# Altium schematic sheet 4_POWER.SchDoc(4_POWER)
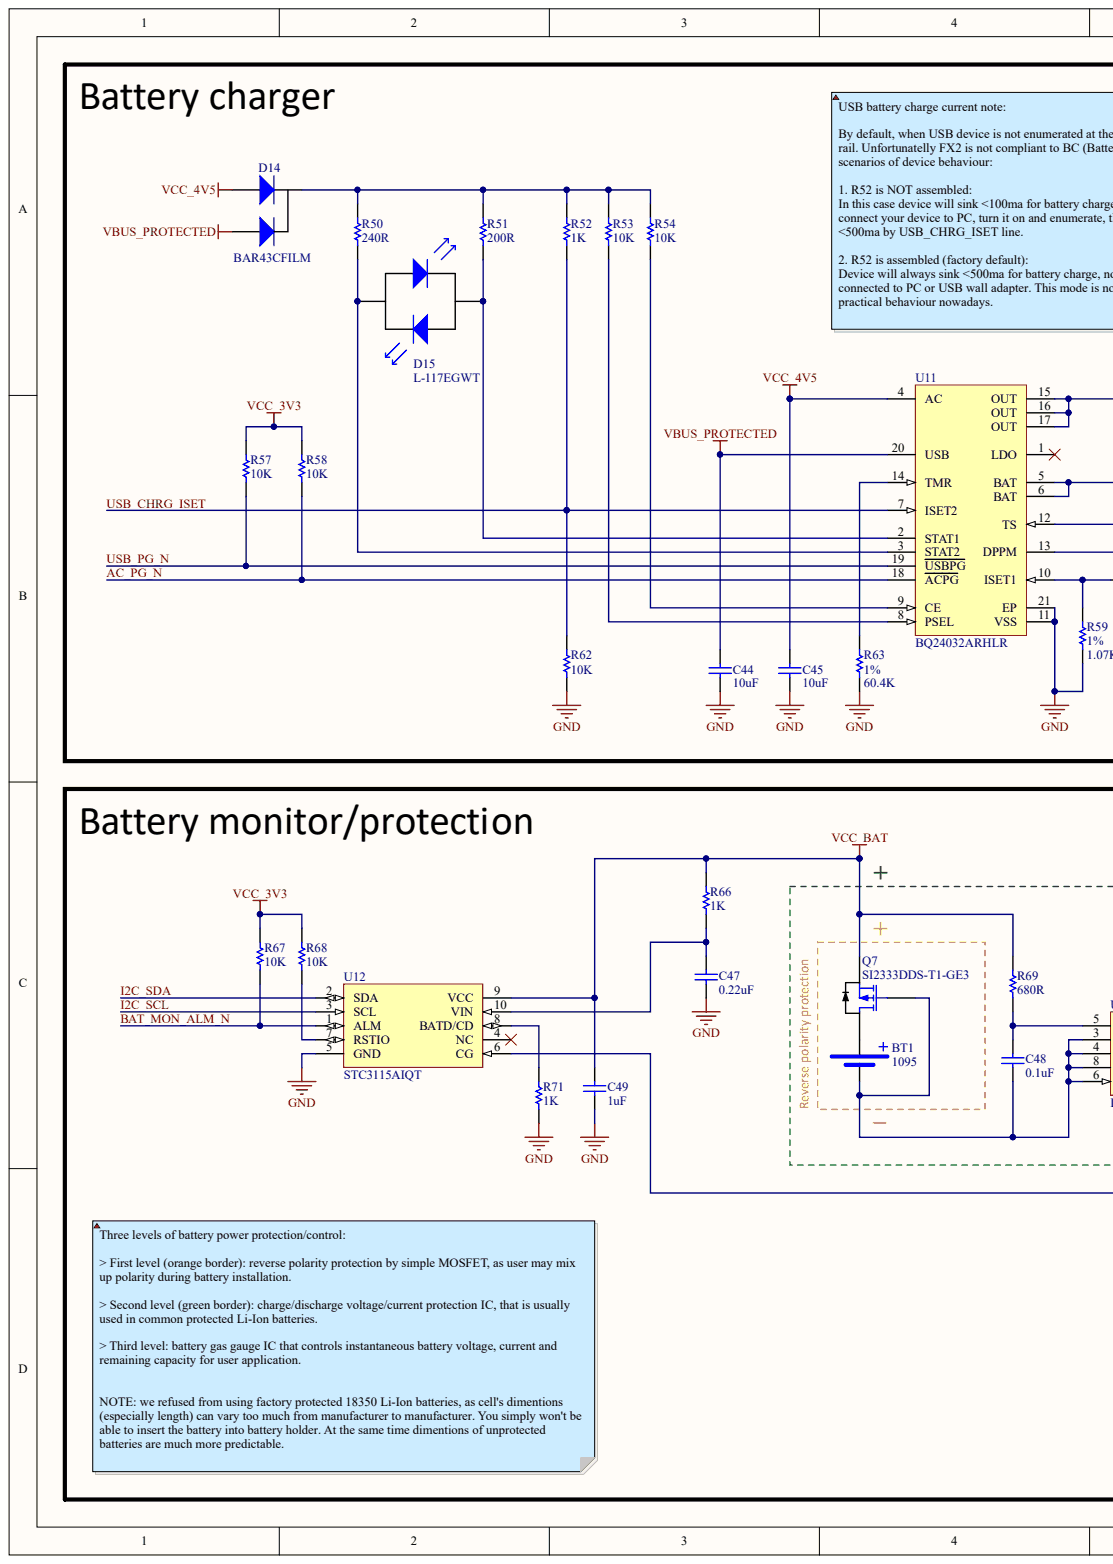
[diagram p0: 4_POWER.SchDoc, left]
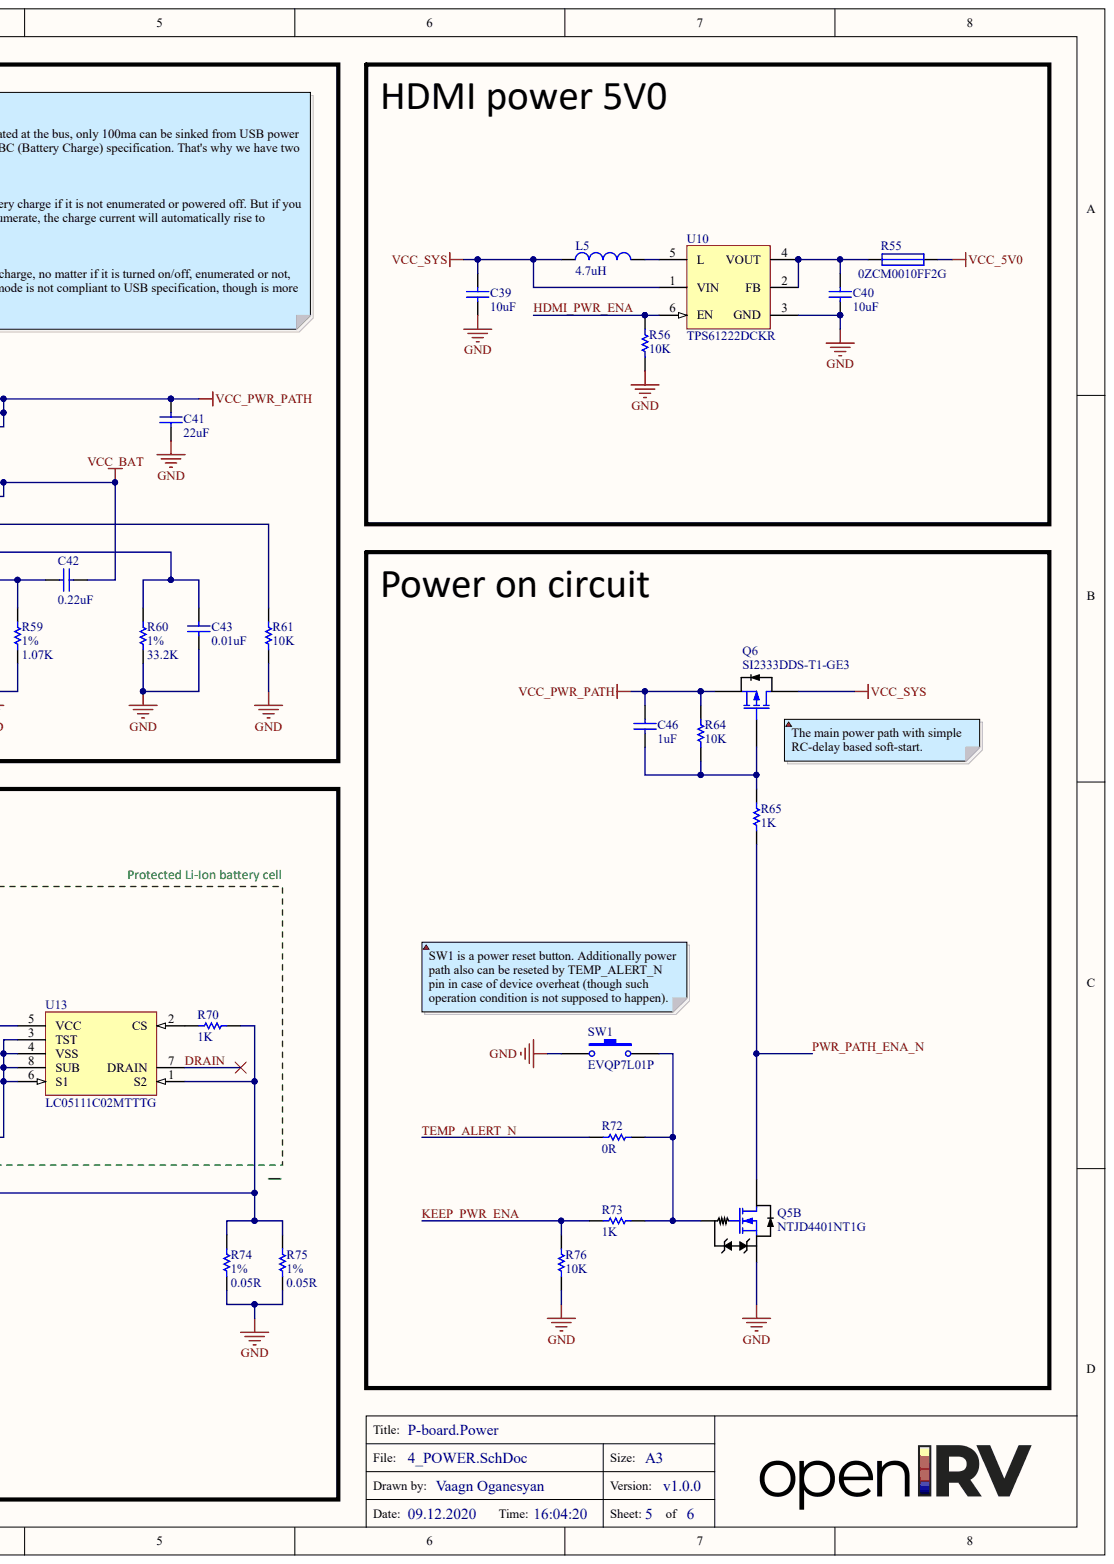
[diagram p1: 4_POWER.SchDoc, right]

## Parts
BT1  1095  [1095]
C39  10uF  [C0805[2012]]
C40  10uF  [C0805[2012]]
C41  22uF  [C1206[3216]]
C42  0.22uF  [C0603[1608]]
C43  0.01uF  [C0402[1005]]
C44  10uF  [C0805[2012]]
C45  10uF  [C0805[2012]]
C46  1uF  [C0603[1608]]
C47  0.22uF  [C0603[1608]]
C48  0.1uF  [C0402[1005]]
C49  1uF  [C0603[1608]]
D14  BAR43CFILM  [BAR43CFILM]
D15  L-117EGWT  [LED_AXIAL[7x5x2]]
L5  value 4.7uH  [L0805[2012]]  (hidden comment: LQM21PN4R7MGRD)
Q5  NTJD4401NT1G  [NTJD4401NT1G]  (part B)
Q6  SI2333DDS-T1-GE3  [SI2333DDS-T1-GE3]
Q7  SI2333DDS-T1-GE3  [SI2333DDS-T1-GE3]
R50  240R  [R0603[1608]]
R51  200R  [R0603[1608]]
R52  1K  [R0402[1005]]
R53  10K  [R0402[1005]]
R54  10K  [R0402[1005]]
R55  0ZCM0010FF2G  [R0603[1608]]
R56  10K  [R0402[1005]]
R57  10K  [R0402[1005]]
R58  10K  [R0402[1005]]
R59  1.07K  Tolerance: 1%  [R0603[1608]]
R60  33.2K  Tolerance: 1%  [R0603[1608]]
R61  10K  [R0402[1005]]
R62  10K  [R0402[1005]]
R63  60.4K  Tolerance: 1%  [R0603[1608]]
R64  10K  [R0402[1005]]
R65  1K  [R0402[1005]]
R66  1K  [R0402[1005]]
R67  10K  [R0402[1005]]
R68  10K  [R0402[1005]]
R69  680R  [R0603[1608]]
R70  1K  [R0402[1005]]
R71  1K  [R0402[1005]]
R72  0R  [R0402[1005]]
R73  1K  [R0402[1005]]
R74  0.05R  Tolerance: 1%  [R1206[3216]]
R75  0.05R  Tolerance: 1%  [R1206[3216]]
R76  10K  [R0402[1005]]
SW1  EVQP7L01P  [EVQP7L01P]
U10  TPS61222DCKR  [TPS61222DCKR]
U11  BQ24032ARHLR  [BQ24032ARHLR]
U12  STC3115AIQT  [STC3115AIQT]
U13  LC05111C02MTTTG  [LC05111C02MTTTG]

## Nets
GND: C39.1 C40.1 C41.1 C43.1 C44.1 C45.1 C47.1 C49.2 Q5.4 R56.2 R59.2 R60.2 R61.1 R62.2 R63.2 R71.1 R74.2 R75.2 R76.1 SW1.2 U10.3(GND) U11.11(VSS) U11.21(EP) U12.5(GND)
VBUS_PROTECTED: C44.2 D14.2 U11.20(USB)
VCC_3V3: R57.2 R58.2 R67.2 R68.2
VCC_4V5: C45.2 D14.1 U11.4(AC)
VCC_5V0: R55.2
VCC_BAT: C42.2 C49.1 Q7.2 R66.2 R69.1 U11.5(BAT) U11.6(BAT) U12.9(VCC)
VCC_PWR_PATH: C41.2 C46.1 Q6.2 R64.1 U11.15(OUT) U11.16(OUT) U11.17(OUT)
VCC_SYS: C39.2 L5.1 Q6.3 U10.1(VIN)
AC_PG_N: R58.1 U11.18(ACPG)
BAT_MON_ALM_N: R67.1 U12.1(ALM)
DRAIN: U13.7(DRAIN)
HDMI_PWR_ENA: R56.1 U10.6(EN)
I2C_SCL: U12.3(SCL)
I2C_SDA: U12.2(SDA)
KEEP_PWR_ENA: R73.2 R76.2
PWR_PATH_ENA_N: Q5.3 R65.2
TEMP_ALERT_N: R72.2
USB_CHRG_ISET: R52.1 R62.1 U11.7(ISET2)
USB_PG_N: R57.1 U11.19(USBPG)
NetBT1_1: BT1.1 Q7.3
NetBT1_2: BT1.2 C48.2 Q7.1 U13.3(TST) U13.4(VSS) U13.6(S1) U13.8(SUB)
NetC40_2: C40.2 R55.1 U10.2(FB) U10.4(VOUT)
NetC42_1: C42.1 R59.1 U11.10(ISET1)
NetC43_2: C43.2 R60.1 U11.13(DPPM)
NetC46_2: C46.2 Q6.1 R64.2 R65.1
NetC47_2: C47.2 R66.1 U12.10(VIN)
NetC48_1: C48.1 R69.2 U13.5(VCC)
NetD14_3: D14.3 R50.1 R51.1 R52.2 R53.2 R54.2
NetD15_1: D15.1 R50.2 U11.3(STAT2)
NetD15_2: D15.2 R51.2 U11.2(STAT1)
NetL5_2: L5.2 U10.5(L)
NetQ5_5: Q5.5 R72.1 R73.1 SW1.1
NetR53_1: R53.1 U11.8(PSEL)
NetR54_1: R54.1 U11.9(CE)
NetR61_2: R61.2 U11.12(TS)
NetR63_1: R63.1 U11.14(TMR)
NetR68_1: R68.1 U12.7(RSTIO)
NetR70_1: R70.1 U13.2(CS)
NetR70_2: R70.2 R74.1 R75.1 U12.6(CG) U13.1(S2)
NetR71_2: R71.2 U12.8(BATD/CD)

Not connected: U11 1(LDO); U12 4(NC)

## Library data of the parts
C39-C41 C44 C45 = CAP: Dielectric: X7R; Tolerance: 10%; Voltage: 10V; Component Kind: Standard; Library Name: general.IntLib; Mounting: SMD
  C39 C40 C44 C45: Value: 10uF
  C41: Value: 22uF
C42 C46 C47 C49 = CAP: Dielectric: X7R; Tolerance: 10%; Voltage: 25V; Component Kind: Standard; Library Name: general.IntLib; Mounting: SMD
  C42 C47: Value: 0.22uF
  C46 C49: Value: 1uF
C43 C48 = CAP: Dielectric: X7R; Tolerance: 10%; Voltage: 50V; Component Kind: Standard; Library Name: general.IntLib; Mounting: SMD
  C43: Value: 0.01uF
  C48: Value: 0.1uF
D14 = Diode_2: Component Kind: Standard; Library Name: general.IntLib
D15 = LED_DUAL: Component Kind: Standard; Library Name: general.IntLib; ManufacturerPartNumber: L-117EGWT
L5 = Inductor: Component Kind: Standard; Library Name: general.IntLib; ManufacturerPartNumber: LQM21PN4R7MGRD
Q5 = NTJD4401NT1G: Dual N-channel mosfet; Component Kind: Standard; Library Name: general.IntLib
R50 R51 = RES: Power: 0.1W; Tolerance: 5%; Component Kind: Standard; Library Name: general.IntLib; Mounting: SMD
  R50: Value: 240R
  R51: Value: 200R
R52-R54 R56-R58 R61 R62 R64-R68 R70-R73 R76 = RES: Power: 0.06W; Tolerance: 5%; Component Kind: Standard; Library Name: general.IntLib; Mounting: SMD
  R52 R65 R66 R70 R71 R73: Value: 1K
  R53 R54 R56-R58 R61 R62 R64 R67 R68 R76: Value: 10K
  R72: Value: 0R
R55 = Fuse: Current: 0.1A; Component Kind: Standard; Library Name: general.IntLib; ManufacturerPartNumber: 0ZCM0010FF2G; Mounting: SMD
R59 R60 R63 = RES: Power: 0.1W; Component Kind: Standard; Library Name: general.IntLib; Mounting: SMD
  R59: Value: 1.07K
  R60: Value: 33.2K
  R63: Value: 60.4K
R69 = RES: Power: 0.1W; Tolerance: 1%; Component Kind: Standard; Library Name: general.IntLib; Mounting: SMD; Value: 680R
R74 R75 = RES: Power: 0.25W; Component Kind: Standard; Library Name: general.IntLib; Mounting: SMD; Value: 0.05R
SW1 = Button: Tactile Switch; Component Kind: Standard; Library Name: general.IntLib; ManufacturerPartNumber: EVQP7L01P
U10 = TPS61222DCKR: DC-DC Boost converter; Component Kind: Standard; Library Name: general.IntLib
U11 = BQ24032ARHLR: Dual Input Li-Ion Charger with Dynamic Power Path, Output regulated to 4.4 V, Vbat 4.2 V, 4.3 V, -40 to 85 degC, 20-pin QFN (RHL), Green (RoHS & no Sb/Br); Manufacturer: Texas Instruments; Lead Acid: N; Li-Ion: Y; SubFamily: Battery Charge Management; Vin(Min)(V): 4.3; Component Kind: Standard; ComponentLink1Description: Datasheet; ComponentLink1URL: http://www.ti.com/general/docs/lit/getliterature.tsp?genericPartNumber=BQ24032A&fileType=pdf; DatasheetVersion: SLUS618H; Eco-Plan: Green (RoHS & no Sb/Br); Li-Polymer: Y; Library Name: general.IntLib; Mounting Technology: Surface Mount; NiCd: N; NiMH: N; Over Voltage Protection: N; PackageDescription: 20-Leads Plastic Quad Flatpack No-Lead, 4.5 x 3.5 x 0.9 mm Body, 0.5 mm Pitch, Exposed Pad; PackageReference: RHL20-3050X2050TP; PackageVersion: revJ, Dec-2011; PartNumber: BQ24032ARHLR; Supplier 1: Digi-Key; Supplier 2: Digi-Key; Supplier 3: Digi-Key; Supplier 4: Newark; Supplier 5: Mouser; Supplier Part Number 1: 296-18285-2-ND; Supplier Part Number 2: 296-18285-1-ND; Supplier Part Number 3: 296-18285-6-ND; Supplier Part Number 4: 43K9063; Supplier Part Number 5: 595-BQ24032ARHLR
U12 = STC3115AIQT: Gas gauge IC with alarm output for handheld applications; Component Kind: Standard; Library Name: general.IntLib
U13 = LC05111C02MTTTG: 1-Cell Lithium-Ion Battery Protection IC with Integrated Power MOSFET; Component Kind: Standard; Library Name: general.IntLib
BT1 = 1095: Component Kind: Standard; Library Name: general.IntLib
Q6 Q7 = SI2333DDS-T1-GE3: Component Kind: Standard; Library Name: general.IntLib
